# Altium schematic sheet PINGUINO_SC.SchDoc
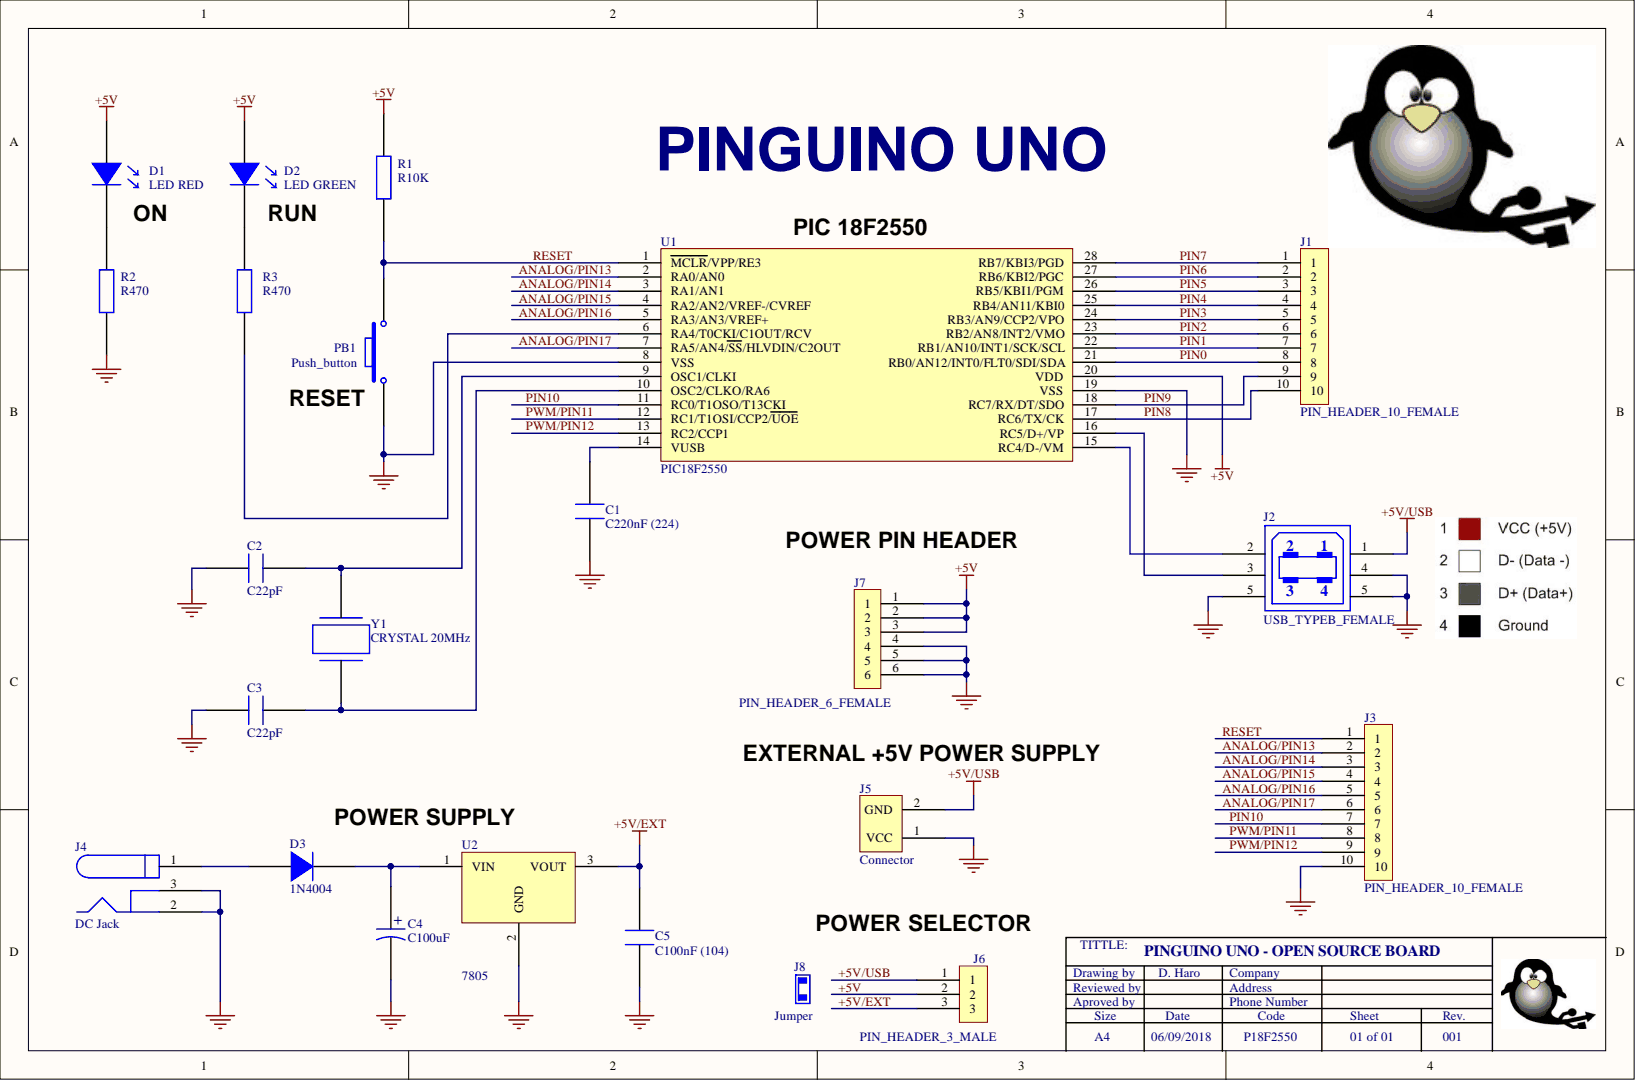
[diagram p0: PINGUINO_SC.SchDoc]

## Parts
C1  C220nF (224)  [C220nF_224_P5mm]
C2  C22pF  [C22pF_P3mm]
C3  C22pF  [C22pF_P3mm]
C4  C100uF  [CAP_100uF]
C5  C100nF (104)  [C100nF - 104 _P3mm]
D1  LED RED  [Diode_led_red_3mm]
D2  LED GREEN  [Diode_led_green_3mm]
D3  1N4004  [1N4004]
J1  PIN_HEADER_10_FEMALE  [PIN_HEADER_10_FEMALE]  (value not drawn)
J2  USB_TYPEB_FEMALE  [USB_TYPEB_FEMALE]
J3  PIN_HEADER_10_FEMALE  [PIN_HEADER_10_FEMALE]  (value not drawn)
J4  DC Jack  [DC_JACK]
J5  Connector  [Connector]
J6  PIN_HEADER_3_MALE  [Pin_header_3_female]
J7  PIN_HEADER_6_FEMALE  [PIN_HEADER__FEMALE]
J8  Jumper
PB1  Push_button  [Push_button]
R1  R10K  [R10K_P8mm_0.25Watt]
R2  R470  [R470_P8mm_0.25Watt]
R3  R470  [R470_P8mm_0.25Watt]
U1  PIC18F2550  [DIP28]
U2  7805  [T0-220]
Y1  CRYSTAL 20MHz  [Crystal 20Mhz]

## Nets
GND: C1.1 C2.1 C3.1 C4.2 C5.1 J2.4 J2.5 J3.10 J4.2 J4.3 J5.1 J7.4 J7.5 J7.6 PB1.1 R2.1 U1.8(VSS) U1.19(VSS) U2.2
+5V: D1.2 D2.2 J6.2 J7.1 J7.2 J7.3 R1.2 U1.20(VDD)
+5V/EXT: C5.2 J6.3 U2.3
+5V/USB: J2.1 J5.2 J6.1
ANALOG/PIN13: J3.2 U1.2(RA0/AN0)
ANALOG/PIN14: J3.3 U1.3(RA1/AN1)
ANALOG/PIN15: J3.4 U1.4(RA2/AN2/VREF-/CVREF)
ANALOG/PIN16: J3.5 U1.5(RA3/AN3/VREF+)
ANALOG/PIN17: J3.6 U1.7(RA5/AN4/SS/HLVDIN/C2OUT)
PIN0: J1.8 U1.21(RB0/AN12/INT0/FLT0/SDI/SDA)
PIN1: J1.7 U1.22(RB1/AN10/INT1/SCK/SCL)
PIN2: J1.6 U1.23(RB2/AN8/INT2/VMO)
PIN3: J1.5 U1.24(RB3/AN9/CCP2/VPO)
PIN4: J1.4 U1.25(RB4/AN11/KBI0)
PIN5: J1.3 U1.26(RB5/KBI1/PGM)
PIN6: J1.2 U1.27(RB6/KBI2/PGC)
PIN7: J1.1 U1.28(RB7/KBI3/PGD)
PIN8: J1.10 U1.17(RC6/TX/CK)
PIN9: J1.9 U1.18(RC7/RX/DT/SDO)
PIN10: J3.7 U1.11(RC0/T1OSO/T13CKI)
PWM/PIN11: J3.8 U1.12(RC1/T1OSI/CCP2/UOE)
PWM/PIN12: J3.9 U1.13(RC2/CCP1)
RESET: J3.1 PB1.2 R1.1 U1.1(MCLR/VPP/RE3)
NetC1_2: C1.2 U1.14(VUSB)
NetC2_2: C2.2 U1.9(OSC1/CLKI) Y1.2
NetC3_2: C3.2 U1.10(OSC2/CLKO/RA6) Y1.1
NetC4_1: C4.1 D3.1 U2.1
NetD1_1: D1.1 R2.2
NetD2_1: D2.1 R3.2
NetD3_2: D3.2 J4.1
NetJ2_2: J2.2 U1.15(RC4/D-/VM)
NetJ2_3: J2.3 U1.16(RC5/D+/VP)
NetR3_1: R3.1 U1.6(RA4/T0CKI/C1OUT/RCV)

## Library data of the parts
C1 = C220nF: Component Kind: Standard; Library Name: PINGUINO_SCLIB.SchLib
C5 = C100nF: Component Kind: Standard; Library Name: PINGUINO_SCLIB.SchLib
D1 = Diode_led_Red_3mm: Component Kind: Standard; Library Name: PINGUINO_SCLIB.SchLib
D2 = Diode_led_Green_3mm: Component Kind: Standard; Library Name: PINGUINO_SCLIB.SchLib
J6 = Pin_header_3: Component Kind: Standard; Library Name: PINGUINO_SCLIB.SchLib
J7 = Pin_header_6: Component Kind: Standard; Library Name: PINGUINO_SCLIB.SchLib
C2 C3 = C22pF: Component Kind: Standard; Library Name: PINGUINO_SCLIB.SchLib
C4 = C100uF: Component Kind: Standard; Library Name: PINGUINO_SCLIB.SchLib
D3 = 1N4004: Component Kind: Standard; Library Name: PINGUINO_SCLIB.SchLib
J1 J3 = PIN_HEADER_10_FEMALE: Component Kind: Standard; Library Name: PINGUINO_SCLIB.SchLib
J2 = USB_TypeB_Female: Component Kind: Standard; Library Name: PINGUINO_SCLIB.SchLib
J4 = DC Jack: Component Kind: Standard; Library Name: PINGUINO_4550.SchLib
J5 = Connector: Component Kind: Standard; Library Name: PINGUINO_SCLIB.SchLib
J8 = Jumper: Component Kind: Standard; Library Name: PINGUINO_SCLIB.SchLib
PB1 = Push_button: Component Kind: Standard; Library Name: PINGUINO_SCLIB.SchLib
R1 = R10K: Component Kind: Standard; Library Name: PINGUINO_SCLIB.SchLib
R2 R3 = R470: Component Kind: Standard; Library Name: PINGUINO_SCLIB.SchLib
U1 = PIC18F2550: Component Kind: Standard; Library Name: PINGUINO_SCLIB.SchLib
U2 = 7805: Component Kind: Standard; Library Name: PIC_MCU.SchLib
Y1 = Crystal 20MHz: Component Kind: Standard; Library Name: PINGUINO_SCLIB.SchLib
